# Altium schematic sheet Display.SchDoc(Display)
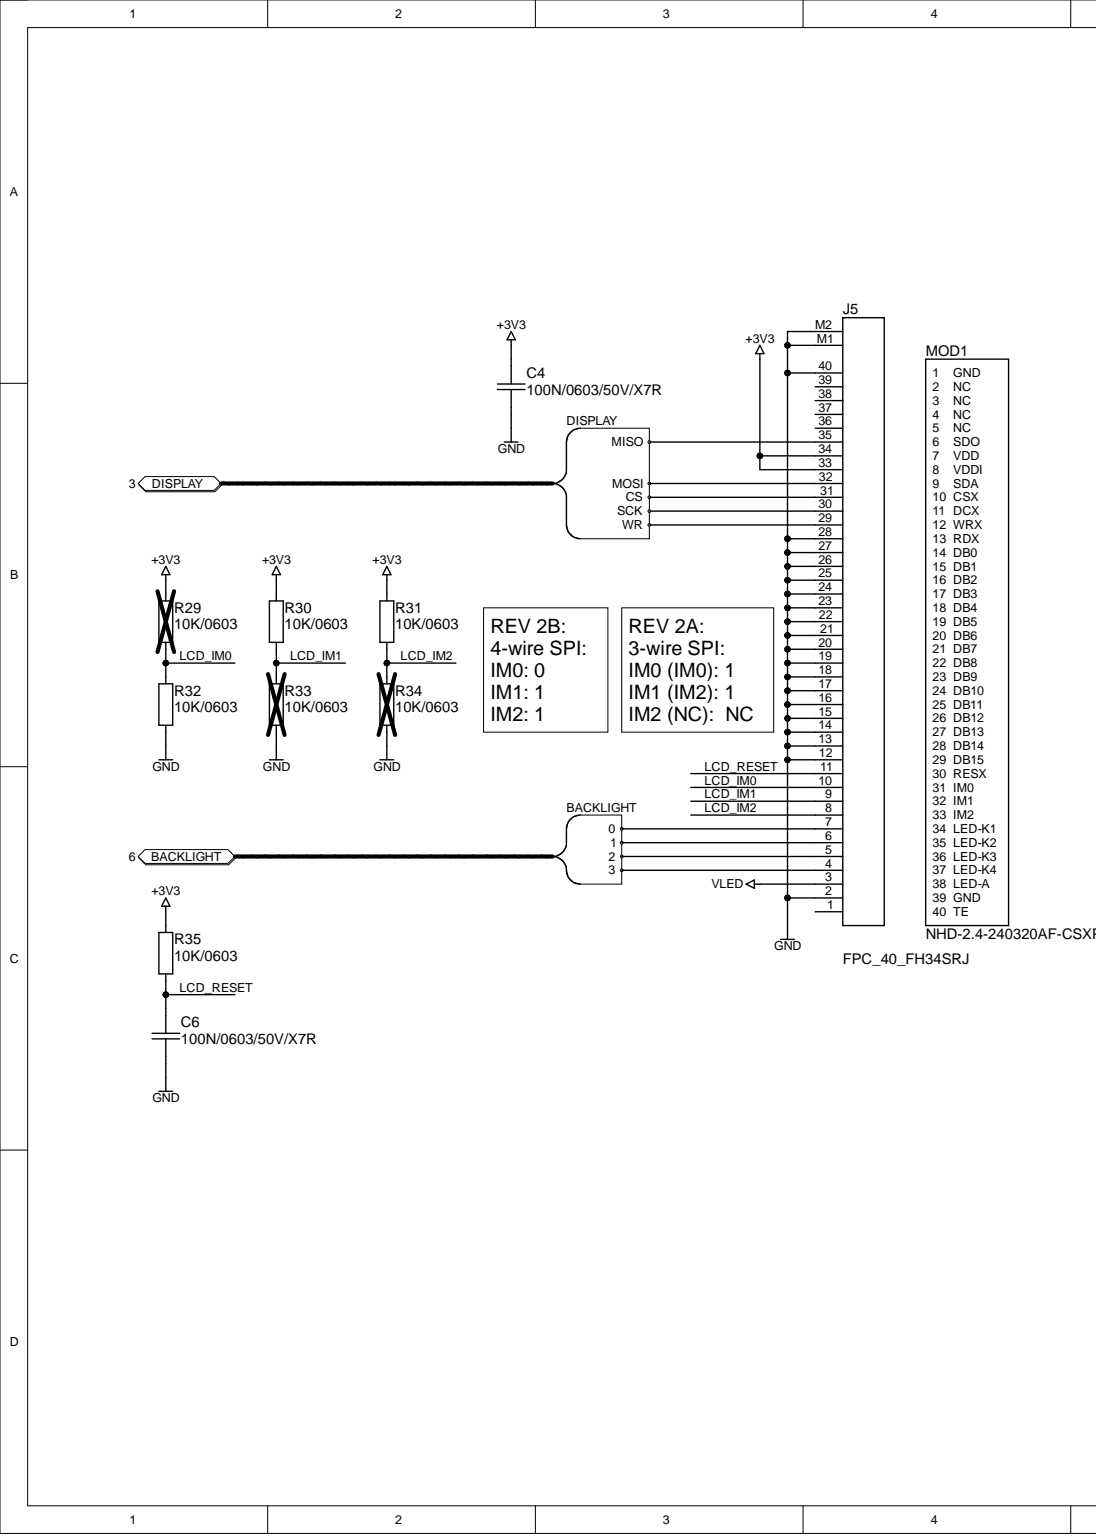
[diagram p0: Display.SchDoc, left]
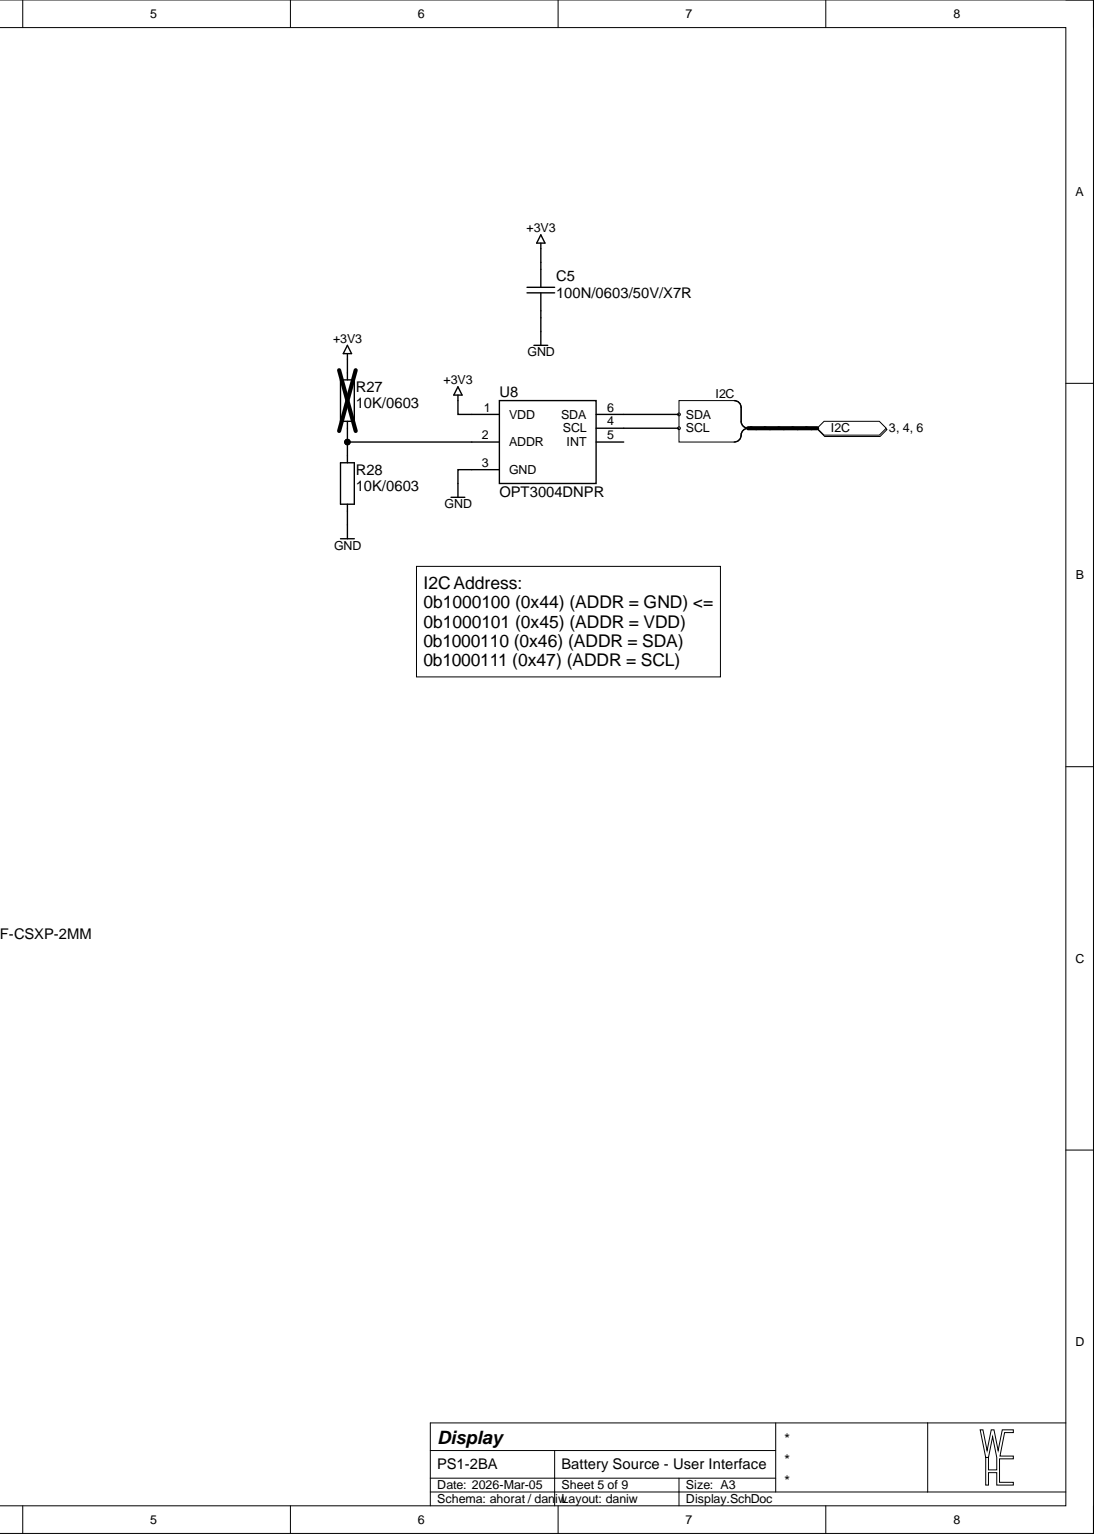
[diagram p1: Display.SchDoc, right]

## Parts
C4  100N/0603/50V/X7R  [CAP0603_X7R]
C5  100N/0603/50V/X7R  [CAP0603_X7R]
C6  100N/0603/50V/X7R  [CAP0603_X7R]
J5  FPC_40_FH34SRJ  [CON_FPC40_FH34SRJ]
MOD1  NHD-2.4-240320AF-CSXP-2MM  [NHD-2.4-240320AF-CSXP-2MM]
R27  10K/0603  [R0603-0.40-WW]
R28  10K/0603  [R0603-0.40-WW]
R29  10K/0603  [R0603-0.40-WW]
R30  10K/0603  [R0603-0.40-WW]
R31  10K/0603  [R0603-0.40-WW]
R32  10K/0603  [R0603-0.40-WW]
R33  10K/0603  [R0603-0.40-WW]
R34  10K/0603  [R0603-0.40-WW]
R35  10K/0603  [R0603-0.40-WW]
U8  OPT3004DNPR  [SON065P200X200X65-6-EP065X130-CLEAR]

## Ports
BACKLIGHT, BACKLIGHT.0, BACKLIGHT.1, BACKLIGHT.2, BACKLIGHT.3, DISPLAY, DISPLAY.CS, DISPLAY.MISO, DISPLAY.MOSI, DISPLAY.SCK, DISPLAY.WR, I2C, I2C.SCL, I2C.SDA

## Nets
+3V3: C4.1 C5.1 J5.33 J5.34 R27.1 R29.1 R30.1 R31.1 R35.1 U8.1(VDD)
GND: C4.2 C5.2 C6.2 J5.2 J5.12 J5.13 J5.14 J5.15 J5.16 J5.17 J5.18 J5.19 J5.20 J5.21 J5.22 J5.23 J5.24 J5.25 J5.26 J5.27 J5.28 J5.40 J5.M1 J5.M2 R28.2 R32.2 R33.2 R34.2 U8.3(GND)
VLED: J5.3
LCD_IM0: J5.10 R29.2 R32.1
LCD_IM1: J5.9 R30.2 R33.1
LCD_IM2: J5.8 R31.2 R34.1
LCD_RESET: C6.1 J5.11 R35.2
NetJ5_1: J5.1
NetJ5_4: J5.4 ; port BACKLIGHT
NetJ5_5: J5.5 ; port BACKLIGHT
NetJ5_6: J5.6 ; port BACKLIGHT
NetJ5_7: J5.7 ; port BACKLIGHT
NetJ5_29: J5.29 ; port DISPLAY
NetJ5_30: J5.30 ; port DISPLAY
NetJ5_31: J5.31 ; port DISPLAY
NetJ5_32: J5.32 ; port DISPLAY
NetJ5_35: J5.35 ; port DISPLAY
NetJ5_36: J5.36
NetJ5_37: J5.37
NetJ5_38: J5.38
NetJ5_39: J5.39
NetR27_2: R27.2 R28.1 U8.2(ADDR)
NetU8_4: U8.4(SCL) ; port I2C
NetU8_5: U8.5(INT)
NetU8_6: U8.6(SDA) ; port I2C

## Library data of the parts
C4-C6 = 100N/0603/50V/X7R: CAP SM 0603 100nF 50V X7R; Manufacturer: GENERIC; Manufacturer 1: YAGEO; Manufacturer Part Number 1: CC0603KPX7R9BB104; Absolute Value: 1E-7; Database Table Name: C$; Eurocircuits GPN: GPC0603104; Component Kind: Standard; HelpURL: https://www.yageo.com/upload/media/product/app/datasheet/mlcc/upy-gphc_x7r_6_3v-to-250v.pdf; JLC Type: Basic; Manufacturer 2: YAGEO; Manufacturer Part Number 2: CC0603MRX7R9BB104; Manufacturer Part Number 3: CC0603KRX7R9BB104; Package: 0603; Part Number: 100N/0603/50V/X7R; Supplier 1: Digi-Key; Supplier 2: Digi-Key; Supplier 3: LCSC; Supplier Part Number 1: 311-3567-1-ND; Supplier Part Number 2: 311-4110-1-ND; Supplier Part Number 3: C14663; Value: 100nF; Library Name: daniw_altium_lib.DbLib
J5 = FPC_40_FH34SRJ: FPC connector, FH34 series, 40 pins; Manufacturer: Hirose; Manufacturer 1: Hirose Electric Co Ltd; Manufacturer Part Number 1: FH34SRJ-40S-0.5SH(50); Database Table Name: CON$; Component Kind: Standard; HelpURL: https://www.hirose.com/en/product/document?clcode=CL0580-1260-6-50&productname=FH34SRJ-40S-0.5SH(50)&series=FH34SRJ&documenttype=2DDrawing&lang=en&documentid=0001483059; JLC Type: Extended; Manufacturer 3: HRS; Manufacturer Part Number 3: FH34SRJ-40S-0.5SH(50); Part Number: FPC_40_FH34SRJ; Supplier 1: Digi-Key; Supplier 3: LCSC; Supplier Part Number 1: H125755CT-ND; Supplier Part Number 3: C506798; System: Connector; Library Name: daniw_altium_lib.DbLib
MOD1 = NHD-2.4-240320AF-CSXP-2MM: NHD-2.4-240320AF-CSXP TFT Liquid Crystal Display, 2.4", 240x320, RGB, high brightness, 2mm above PCB; Manufacturer: Newhaven Display; Manufacturer 1: Newhaven Display Intl; Database Table Name: Module$; Component Kind: Standard; HelpURL: https://newhavendisplay.com/content/specs/NHD-2.4-240320AF-CSXP.pdf; Manufacturer Part Number 1: NHD-2.4-240320AF-CSXP; Package: Display Module; Part Number: NHD-2.4-240320AF-CSXP-2MM; Supplier 1: Digi-Key; Supplier Part Number 1: 757-NHD-2.4-240320AF-CSXP-ND; Library Name: daniw_altium_lib.DbLib
R27-R35 = 10K/0603: RES SM 0603 10K; Manufacturer: GENERIC; Manufacturer 1: YAGEO; Manufacturer Part Number 1: RC0603FR-1310KL; Absolute Value: 10000; Database Table Name: R$; Eurocircuits GPN: GPR060310K; Component Kind: Standard; HelpURL: https://www.yageogroup.com/content/datasheet/asset/file/PYU-RC_GROUP_51_ROHS_L; JLC Type: Basic; Manufacturer 2: YAGEO; Manufacturer 3: UNI-ROYAL(Uniroyal Elec); Manufacturer Part Number 2: RC0603FR-1010KL; Manufacturer Part Number 3: 0603WAF1002T5E; Package: 0603; Part Number: 10K/0603; Supplier 1: Digi-Key; Supplier 2: Digi-Key; Supplier 3: LCSC; Supplier Part Number 1: 13-RC0603FR-1310KLCT-ND; Supplier Part Number 2: 13-RC0603FR-1010KLCT-ND; Supplier Part Number 3: C25804; Value: 10K; Library Name: daniw_altium_lib.DbLib
U8 = OPT3004DNPR: OPT3004DNPR, OPT3004 Ambient Light Sensor (ALS) With Excellent Angular IR Rejection, USON8; Manufacturer: Texas Instruments; Database Table Name: Integrated$; Component Kind: Standard; HelpURL: https://www.ti.com/lit/ds/symlink/opt3004.pdf; JLC Type: Extended; Manufacturer 1: Texas Instruments; Manufacturer 3: TI; Manufacturer Part Number 1: OPT3004DNPR; Manufacturer Part Number 3: OPT3004DNPR; Package: USON-8; Part Number: OPT3004DNPR; Supplier 1: Digi-Key; Supplier 3: LCSC; Supplier Part Number 1: 296-53402-6-ND; Supplier Part Number 3: C2655153; Library Name: daniw_altium_lib.DbLib
